# Altium schematic sheet Configuration Switches and DAC for T to E.SchDoc("Configuration Switches and DAC for T to E")
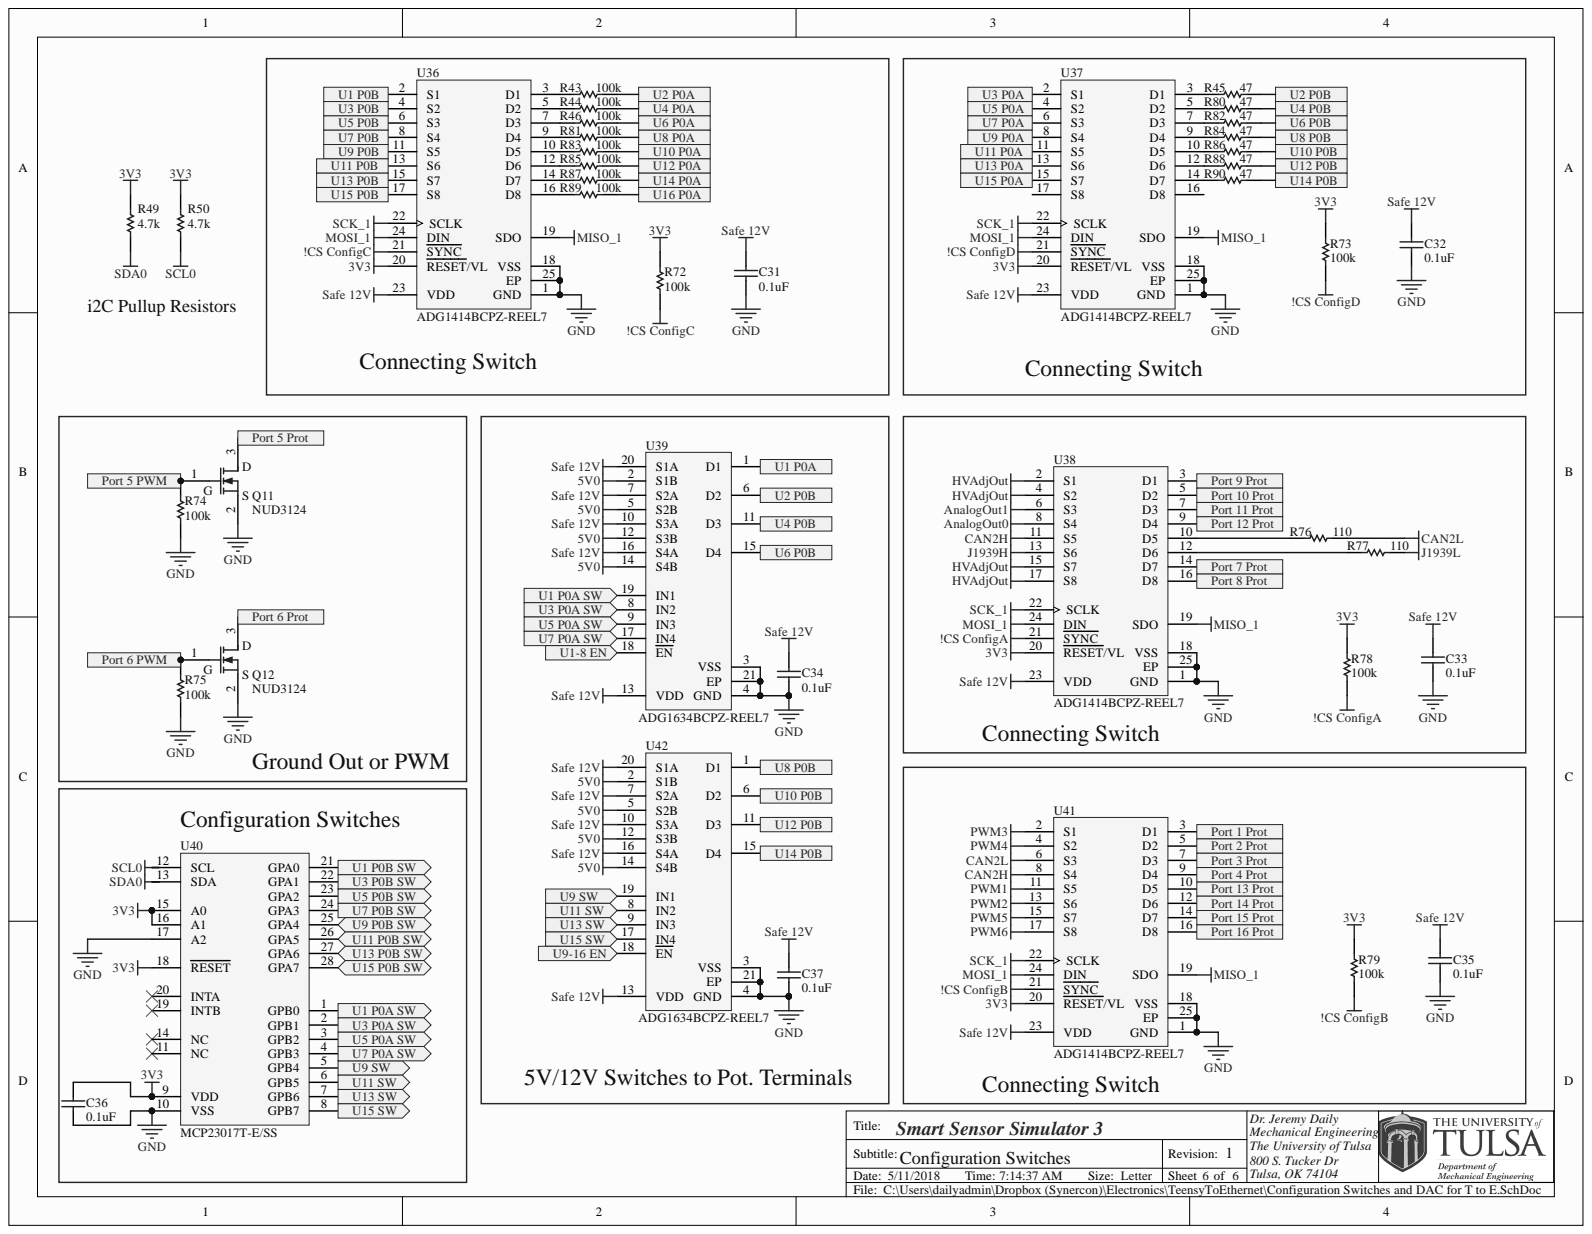
[diagram p0: Configuration Switches and DAC for T to E.SchDoc]

## Parts
C31  0.1uF  [0603]
C32  0.1uF  [0603]
C33  0.1uF  [0603]
C34  0.1uF  [0603]
C35  0.1uF  [0603]
C36  0.1uF  [0603]
C37  0.1uF  [0603]
Q11  NUD3124  [ONSC-CPH-3_V]
Q12  NUD3124  [ONSC-CPH-3_V]
R43  100k  [0603]
R44  100k  [0603]
R45  47  [0603]
R46  100k  [0603]
R49  4.7k  [0603]
R50  4.7k  [0603]
R72  100k  [0603]
R73  100k  [0603]
R74  100k  [0603]
R75  100k  [0603]
R76  110  [0603]
R77  110  [0603]
R78  100k  [0603]
R79  100k  [0603]
R80  47  [0603]
R81  100k  [0603]
R82  47  [0603]
R83  100k  [0603]
R84  47  [0603]
R85  100k  [0603]
R86  47  [0603]
R87  100k  [0603]
R88  47  [0603]
R89  100k  [0603]
R90  47  [0603]
U36  ADG1414BCPZ-REEL7  [ADI-CP-24-3_M]
U37  ADG1414BCPZ-REEL7  [ADI-CP-24-3_M]
U38  ADG1414BCPZ-REEL7  [ADI-CP-24-3_M]
U39  ADG1634BCPZ-REEL7  [ADI-CP-20-4_N]
U40  MCP23017T-E/SS  [SSOP-SS28_N]
U41  ADG1414BCPZ-REEL7  [ADI-CP-24-3_M]
U42  ADG1634BCPZ-REEL7  [ADI-CP-20-4_N]

## Ports
Port 1 Prot, Port 2 Prot, Port 3 Prot, Port 4 Prot, Port 5 Prot, Port 5 PWM, Port 6 Prot, Port 6 PWM, Port 7 Prot, Port 8 Prot, Port 9 Prot, Port 10 Prot, Port 11 Prot, Port 12 Prot, Port 13 Prot, Port 14 Prot, Port 15 Prot, Port 16 Prot, U1 P0A, U1 P0A SW, U1 P0B, U1 P0B SW, U1-8 EN, U2 P0A, U2 P0B, U3 P0A, U3 P0A SW, U3 P0B, U3 P0B SW, U4 P0A, U4 P0B, U5 P0A, U5 P0A SW, U5 P0B, U5 P0B SW, U6 P0A, U6 P0B, U7 P0A, U7 P0A SW, U7 P0B, U7 P0B SW, U8 P0A, U8 P0B, U9 P0A, U9 P0B, U9 P0B SW, U9 SW, U9-16 EN, U10 P0A, U10 P0B, U11 P0A, U11 P0B, U11 P0B SW, U11 SW, U12 P0A, U12 P0B, U13 P0A, U13 P0B, U13 P0B SW, U13 SW, U14 P0A, U14 P0B, U15 P0A, U15 P0B, U15 P0B SW, U15 SW, U16 P0A

## Nets
3V3: C36.2 R49.1 R50.1 R72.2 R73.2 R78.2 R79.2 U36.20(RESET/VL) U37.20(RESET/VL) U38.20(RESET/VL) U40.9(VDD) U40.15(A0) U40.16(A1) U40.18(RESET) U41.20(RESET/VL)
5V0: U39.2(S1B) U39.5(S2B) U39.12(S3B) U39.14(S4B) U42.2(S1B) U42.5(S2B) U42.12(S3B) U42.14(S4B)
!CS ConfigA: R78.1 U38.21(SYNC)
!CS ConfigB: R79.1 U41.21(SYNC)
!CS ConfigC: R72.1 U36.21(SYNC)
!CS ConfigD: R73.1 U37.21(SYNC)
AnalogOut0: U38.8(S4)
AnalogOut1: U38.6(S3)
CAN2H: U38.11(S5) U41.8(S4)
CAN2L: R76.2 U41.6(S3)
GND: C31.1 C32.1 C33.1 C34.1 C35.1 C36.1 C37.1 Q11.2 Q12.2 R74.1 R75.1 U36.1(GND) U36.18(VSS) U36.25(EP) U37.1(GND) U37.18(VSS) U37.25(EP) U38.1(GND) U38.18(VSS) U38.25(EP) U39.3(VSS) U39.4(GND) U39.21(EP) U40.10(VSS) U40.17(A2) U41.1(GND) U41.18(VSS) U41.25(EP) U42.3(VSS) U42.4(GND) U42.21(EP)
HVAdjOut: U38.2(S1) U38.4(S2) U38.15(S7) U38.17(S8)
J1939H: U38.13(S6)
J1939L: R77.2
MISO_1: U36.19(SDO) U37.19(SDO) U38.19(SDO) U41.19(SDO)
MOSI_1: U36.24(DIN) U37.24(DIN) U38.24(DIN) U41.24(DIN)
PWM1: U41.11(S5)
PWM2: U41.13(S6)
PWM3: U41.2(S1)
PWM4: U41.4(S2)
PWM5: U41.15(S7)
PWM6: U41.17(S8)
Safe 12V: C31.2 C32.2 C33.2 C34.2 C35.2 C37.2 U36.23(VDD) U37.23(VDD) U38.23(VDD) U39.7(S2A) U39.10(S3A) U39.13(VDD) U39.16(S4A) U39.20(S1A) U41.23(VDD) U42.7(S2A) U42.10(S3A) U42.13(VDD) U42.16(S4A) U42.20(S1A)
SCK_1: U36.22(SCLK) U37.22(SCLK) U38.22(SCLK) U41.22(SCLK)
SCL0: R50.2 U40.12(SCL)
SDA0: R49.2 U40.13(SDA)
Port 1 Prot: U41.3(D1) ; port Port 1 Prot
Port 2 Prot: U41.5(D2) ; port Port 2 Prot
Port 3 Prot: U41.7(D3) ; port Port 3 Prot
Port 4 Prot: U41.9(D4) ; port Port 4 Prot
Port 5 Prot: Q11.3 ; port Port 5 Prot
Port 5 PWM: Q11.1 R74.2 ; port Port 5 PWM
Port 6 Prot: Q12.3 ; port Port 6 Prot
Port 6 PWM: Q12.1 R75.2 ; port Port 6 PWM
Port 7 Prot: U38.14(D7) ; port Port 7 Prot
Port 8 Prot: U38.16(D8) ; port Port 8 Prot
Port 9 Prot: U38.3(D1) ; port Port 9 Prot
Port 10 Prot: U38.5(D2) ; port Port 10 Prot
Port 11 Prot: U38.7(D3) ; port Port 11 Prot
Port 12 Prot: U38.9(D4) ; port Port 12 Prot
Port 13 Prot: U41.10(D5) ; port Port 13 Prot
Port 14 Prot: U41.12(D6) ; port Port 14 Prot
Port 15 Prot: U41.14(D7) ; port Port 15 Prot
Port 16 Prot: U41.16(D8) ; port Port 16 Prot
U1 P0A: U39.1(D1) ; port U1 P0A
U1 P0A SW: U39.19(IN1) U40.1(GPB0) ; port U1 P0A SW
U1 P0B: U36.2(S1) ; port U1 P0B
U1 P0B SW: U40.21(GPA0) ; port U1 P0B SW
U1-8 EN: U39.18(EN) ; port U1-8 EN
U2 P0A: R43.1 ; port U2 P0A
U2 P0B: R45.2 U39.6(D2) ; port U2 P0B
U3 P0A: U37.2(S1) ; port U3 P0A
U3 P0A SW: U39.8(IN2) U40.2(GPB1) ; port U3 P0A SW
U3 P0B: U36.4(S2) ; port U3 P0B
U3 P0B SW: U40.22(GPA1) ; port U3 P0B SW
U4 P0A: R44.1 ; port U4 P0A
U4 P0B: R80.2 U39.11(D3) ; port U4 P0B
U5 P0A: U37.4(S2) ; port U5 P0A
U5 P0A SW: U39.9(IN3) U40.3(GPB2) ; port U5 P0A SW
U5 P0B: U36.6(S3) ; port U5 P0B
U5 P0B SW: U40.23(GPA2) ; port U5 P0B SW
U6 P0A: R46.1 ; port U6 P0A
U6 P0B: R82.2 U39.15(D4) ; port U6 P0B
U7 P0A: U37.6(S3) ; port U7 P0A
U7 P0A SW: U39.17(IN4) U40.4(GPB3) ; port U7 P0A SW
U7 P0B: U36.8(S4) ; port U7 P0B
U7 P0B SW: U40.24(GPA3) ; port U7 P0B SW
U8 P0A: R81.1 ; port U8 P0A
U8 P0B: R84.2 U42.1(D1) ; port U8 P0B
U9 P0A: U37.8(S4) ; port U9 P0A
U9 P0B: U36.11(S5) ; port U9 P0B
U9 P0B SW: U40.25(GPA4) ; port U9 P0B SW
U9 SW: U40.5(GPB4) U42.19(IN1) ; port U9 SW
U9-16 EN: U42.18(EN) ; port U9-16 EN
U10 P0A: R83.1 ; port U10 P0A
U10 P0B: R86.2 U42.6(D2) ; port U10 P0B
U11 P0A: U37.11(S5) ; port U11 P0A
U11 P0B: U36.13(S6) ; port U11 P0B
U11 P0B SW: U40.26(GPA5) ; port U11 P0B SW
U11 SW: U40.6(GPB5) U42.8(IN2) ; port U11 SW
U12 P0A: R85.1 ; port U12 P0A
U12 P0B: R88.2 U42.11(D3) ; port U12 P0B
U13 P0A: U37.13(S6) ; port U13 P0A
U13 P0B: U36.15(S7) ; port U13 P0B
U13 P0B SW: U40.27(GPA6) ; port U13 P0B SW
U13 SW: U40.7(GPB6) U42.9(IN3) ; port U13 SW
U14 P0A: R87.1 ; port U14 P0A
U14 P0B: R90.2 U42.15(D4) ; port U14 P0B
U15 P0A: U37.15(S7) ; port U15 P0A
U15 P0B: U36.17(S8) ; port U15 P0B
U15 P0B SW: U40.28(GPA7) ; port U15 P0B SW
U15 SW: U40.8(GPB7) U42.17(IN4) ; port U15 SW
U16 P0A: R89.1 ; port U16 P0A
NetR43_2: R43.2 U36.3(D1)
NetR44_2: R44.2 U36.5(D2)
NetR45_1: R45.1 U37.3(D1)
NetR46_2: R46.2 U36.7(D3)
NetR76_1: R76.1 U38.10(D5)
NetR77_1: R77.1 U38.12(D6)
NetR80_1: R80.1 U37.5(D2)
NetR81_2: R81.2 U36.9(D4)
NetR82_1: R82.1 U37.7(D3)
NetR83_2: R83.2 U36.10(D5)
NetR84_1: R84.1 U37.9(D4)
NetR85_2: R85.2 U36.12(D6)
NetR86_1: R86.1 U37.10(D5)
NetR87_2: R87.2 U36.14(D7)
NetR88_1: R88.1 U37.12(D6)
NetR89_2: R89.2 U36.16(D8)
NetR90_1: R90.1 U37.14(D7)

Not connected: U37 16(D8) 17(S8); U40 11(NC) 14(NC) 19(INTB) 20(INTA)

## Library data of the parts
C31-C37 = Cap: 0.1µF -20%, +80% 25V Ceramic Capacitor Y5V (F) 0603 (1608 Metric); PartNumber: 06033G104ZAT2A; Manufacturer: AVX Corporation; Alternative: Acceptable; Supplier Part Number 1: 478-1259-1-ND; Supplier 1: Digi-Key; Simulation: CAP; Signal Integrity: Cap; Library Name: SSS Integrated Library.IntLib; Component Kind: Standard
Q11 Q12 = IRLML6344TRPbF: IC INDCT LOAD DRVR AUTO SOT23; PartNumber: NUD3124LT1G; Manufacturer: ON Semiconductor; Alternative: None; Supplier Part Number 1: NUD3124LT1GOSCT-ND; Supplier 1: Digi-Key; Library Name: SSS Integrated Library.IntLib; Component Kind: Standard
R43 R44 R46 R72-R75 R78 R79 R81 R83 R85 R87 R89 = Chip Resistor: 100 kOhms ±1% 0.1W, 1/10W Chip Resistor 0603 (1608 Metric) Automotive AEC-Q200 Thick Film; PartNumber: ERJ-3EKF1003V; Manufacturer: Panasonic Electronic Components; Alternative: Acceptable; Supplier Part Number 1: P100KHCT-ND; Supplier 1: Digi-Key; Library Name: SSS Integrated Library.IntLib; Component Kind: Standard
R45 R80 R82 R84 R86 R88 R90 = Chip Resistor: 47 Ohms ±1% 0.1W, 1/10W Chip Resistor 0603 (1608 Metric) Automotive AEC-Q200 Thick Film; PartNumber: ERJ-3EKF47R0V; Manufacturer: Panasonic Electronic Components; Alternative: Acceptable; Supplier Part Number 1: P47.0HCT-ND; Supplier 1: Digi-Key; Library Name: SSS Integrated Library.IntLib; Component Kind: Standard
R49 R50 = Chip Resistor: 4.7 kOhms ±1% 0.1W, 1/10W Chip Resistor 0603 (1608 Metric) Automotive AEC-Q200 Thick Film; PartNumber: ERJ-3EKF4701V; Manufacturer: Panasonic Electronic Components; Alternative: Acceptable; Supplier Part Number 1: P4.70KHCT-ND; Supplier 1: Digi-Key; Library Name: SSS Integrated Library.IntLib; Component Kind: Standard
R76 R77 = Chip Resistor: RES SMD 110 OHM 1% 1/10W 0603; PartNumber: ERJ-3EKF1100V; Manufacturer: Panasonic Electronic Components; Alternative: Acceptable; Supplier Part Number 1: P110HCT-ND; Supplier 1: Digi-Key; Library Name: SSS Integrated Library.IntLib; Component Kind: Standard
U36-U38 U41 = ADG1414BCPZ-REEL7: 9.5 Ohm RON +/-15 V / +12 V / +/-5 V iCMOS Serially-Controlled Octal SPST Switch, -40 to 125 degC, 24-pin QFN (CP-24-3), Reel; Manufacturer: Analog Devices; Alternative: None; Supplier Part Number 1: ADG1414BCPZ-REEL7TR-ND; Supplier 1: Digi-Key; PartNumber: ADG1414BCPZ-REEL7; Library Name: Analog Devices Switches and Multiplexers Analog Switches.IntLib; Component Kind: Standard
U39 U42 = ADG1634BCPZ-REEL7: IC SWITCH QUAD SPDT 20LFCSP; Manufacturer: Analog Devices; Alternative: None; Supplier Part Number 1: ADG1634BCPZ-REEL7CT-ND; Supplier 1: Digi-Key; PartNumber: ADG1634BCPZ-REEL7; Library Name: Analog Devices Switches and Multiplexers Analog Switches.IntLib; Component Kind: Standard
U40 = MCP23017T-E/SS: 16-Bit I/O Expander with Serial Interface, 28-Pin SSOP, Extended Temperature, Tape and Reel; Manufacturer: Microchip; Alternative: None; Supplier Part Number 1: MCP23017T-E/SSCT-ND; Supplier 1: Digi-Key; PartNumber: MCP23017T-E/SS; Library Name: Microchip Interface.IntLib; Component Kind: Standard
